# Altium schematic sheet Beagle.SchDoc
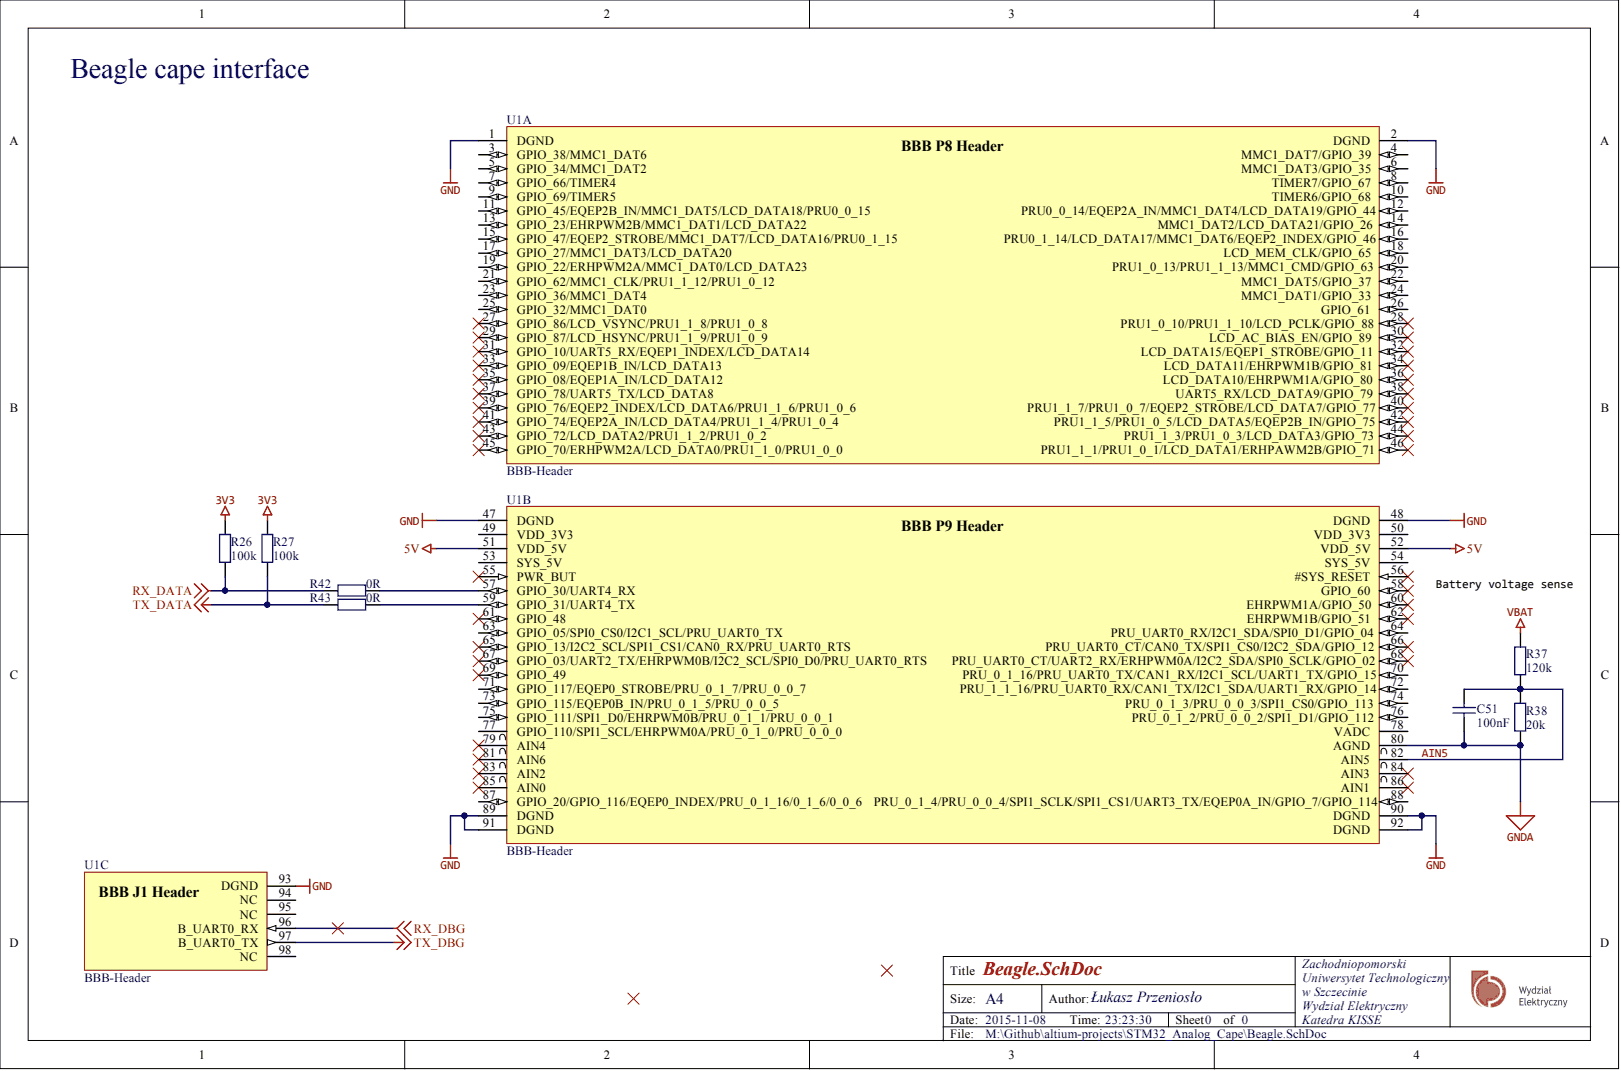
[diagram p0: Beagle.SchDoc]

## Parts
C51  100nF  [CAPC1608N]
R26  100k  [RESC1608N]
R27  100k  [RESC1608N]
R37  120k  [RESC1608N]
R38  20k  [RESC1608N]
R42  0R  [RESC1608N]
R43  0R  [RESC1608N]
U1  BBB-Header  [BeagleBone Black No Bat]  (parts A,B,C)

## Nets
3V3: R26.1 R27.1
5V: U1.51(VDD_5V) U1.52(VDD_5V)
GND: U1.1(DGND) U1.2(DGND) U1.47(DGND) U1.48(DGND) U1.89(DGND) U1.90(DGND) U1.91(DGND) U1.92(DGND) U1.93(DGND)
GNDA: C51.1 R38.1 U1.80(AGND)
RX_DATA: R26.2 R42.2
RX_DBG: U1.96(B_UART0_RX)
TX_DATA: R27.2 R43.2
TX_DBG: U1.97(B_UART0_TX)
VBAT: R37.2
AIN5: C51.2 R37.1 R38.2 U1.82(AIN5)
NetR42_1: R42.1 U1.57(GPIO_30/UART4_RX)
NetR43_1: R43.1 U1.59(GPIO_31/UART4_TX)

Not connected: U1 3(GPIO_38/MMC1_DAT6) 4(MMC1_DAT7/GPIO_39) 5(GPIO_34/MMC1_DAT2) 6(MMC1_DAT3/GPIO_35) 7(GPIO_66/TIMER4) 8(TIMER7/GPIO_67) 9(GPIO_69/TIMER5) 10(TIMER6/GPIO_68) 11(GPIO_45/EQEP2B_IN/MMC1_DAT5/LCD_DATA18/PRU0_0_15) 12(PRU0_0_14/EQEP2A_IN/MMC1_DAT4/LCD_DATA19/GPIO_44) 13(GPIO_23/EHRPWM2B/MMC1_DAT1/LCD_DATA22) 14(MMC1_DAT2/LCD_DATA21/GPIO_26) 15(GPIO_47/EQEP2_STROBE/MMC1_DAT7/LCD_DATA16/PRU0_1_15) 16(PRU0_1_14/LCD_DATA17/MMC1_DAT6/EQEP2_INDEX/GPIO_46) 17(GPIO_27/MMC1_DAT3/LCD_DATA20) 18(LCD_MEM_CLK/GPIO_65) 19(GPIO_22/ERHPWM2A/MMC1_DAT0/LCD_DATA23) 20(PRU1_0_13/PRU1_1_13/MMC1_CMD/GPIO_63) 21(GPIO_62/MMC1_CLK/PRU1_1_12/PRU1_0_12) 22(MMC1_DAT5/GPIO_37) 23(GPIO_36/MMC1_DAT4) 24(MMC1_DAT1/GPIO_33) 25(GPIO_32/MMC1_DAT0) 26(GPIO_61) 27(GPIO_86/LCD_VSYNC/PRU1_1_8/PRU1_0_8) 28(PRU1_0_10/PRU1_1_10/LCD_PCLK/GPIO_88) 29(GPIO_87/LCD_HSYNC/PRU1_1_9/PRU1_0_9) 30(LCD_AC_BIAS_EN/GPIO_89) 31(GPIO_10/UART5_RX/EQEP1_INDEX/LCD_DATA14) 32(LCD_DATA15/EQEP1_STROBE/GPIO_11) 33(GPIO_09/EQEP1B_IN/LCD_DATA13) 34(LCD_DATA11/EHRPWM1B/GPIO_81) 35(GPIO_08/EQEP1A_IN/LCD_DATA12) 36(LCD_DATA10/EHRPWM1A/GPIO_80) 37(GPIO_78/UART5_TX/LCD_DATA8) 38(UART5_RX/LCD_DATA9/GPIO_79) 39(GPIO_76/EQEP2_INDEX/LCD_DATA6/PRU1_1_6/PRU1_0_6) 40(PRU1_1_7/PRU1_0_7/EQEP2_STROBE/LCD_DATA7/GPIO_77) 41(GPIO_74/EQEP2A_IN/LCD_DATA4/PRU1_1_4/PRU1_0_4) 42(PRU1_1_5/PRU1_0_5/LCD_DATA5/EQEP2B_IN/GPIO_75) 43(GPIO_72/LCD_DATA2/PRU1_1_2/PRU1_0_2) 44(PRU1_1_3/PRU1_0_3/LCD_DATA3/GPIO_73) 45(GPIO_70/ERHPWM2A/LCD_DATA0/PRU1_1_0/PRU1_0_0) 46(PRU1_1_1/PRU1_0_1/LCD_DATA1/ERHPAWM2B/GPIO_71) 49(VDD_3V3) 50(VDD_3V3) 53(SYS_5V) 54(SYS_5V) 55(PWR_BUT) 56(#SYS_RESET) 58(GPIO_60) 60(EHRPWM1A/GPIO_50) 61(GPIO_48) 62(EHRPWM1B/GPIO_51) 63(GPIO_05/SPI0_CS0/I2C1_SCL/PRU_UART0_TX) 64(PRU_UART0_RX/I2C1_SDA/SPI0_D1/GPIO_04) 65(GPIO_13/I2C2_SCL/SPI1_CS1/CAN0_RX/PRU_UART0_RTS) 66(PRU_UART0_CT/CAN0_TX/SPI1_CS0/I2C2_SDA/GPIO_12) 67(GPIO_03/UART2_TX/EHRPWM0B/I2C2_SCL/SPI0_D0/PRU_UART0_RTS) 68(PRU_UART0_CT/UART2_RX/ERHPWM0A/I2C2_SDA/SPI0_SCLK/GPIO_02) 69(GPIO_49) 70(PRU_0_1_16/PRU_UART0_TX/CAN1_RX/I2C1_SCL/UART1_TX/GPIO_15) 71(GPIO_117/EQEP0_STROBE/PRU_0_1_7/PRU_0_0_7) 72(PRU_1_1_16/PRU_UART0_RX/CAN1_TX/I2C1_SDA/UART1_RX/GPIO_14) 73(GPIO_115/EQEP0B_IN/PRU_0_1_5/PRU_0_0_5) 74(PRU_0_1_3/PRU_0_0_3/SPI1_CS0/GPIO_113) 75(GPIO_111/SPI1_D0/EHRPWM0B/PRU_0_1_1/PRU_0_0_1) 76(PRU_0_1_2/PRU_0_0_2/SPI1_D1/GPIO_112) 77(GPIO_110/SPI1_SCL/EHRPWM0A/PRU_0_1_0/PRU_0_0_0) 78(VADC) 79(AIN4) 81(AIN6) 83(AIN2) 84(AIN3) 85(AIN0) 86(AIN1) 87(GPIO_20/GPIO_116/EQEP0_INDEX/PRU_0_1_16/0_1_6/0_0_6) 88(PRU_0_1_4/PRU_0_0_4/SPI1_SCLK/SPI1_CS1/UART3_TX/EQEP0A_IN/GPIO_7/GPIO_114) 94(NC) 95(NC) 98(NC)

## Library data of the parts
C51 = Capacitor: Component Kind: Standard; Description: Capacitor; Library Name: Discrete.SchLib; Signal Integrity: Cap; Simulation: CAP; Value: 100nF
R26 R27 R37 R38 R42 R43 = Resistor: Power: 0W; Tolerance: 5%; Component Kind: Standard; Description: Resistor; Library Name: Discrete.SchLib; Signal Integrity: Res; Simulation: RESISTOR
  R26 R27: Value: 100k
  R37: Value: 120k
  R38: Value: 20k
  R42 R43: Value: 0R
U1 = Beaglebone Black No Bat: Component Kind: Standard; Library Name: my_various_devices.SchLib
